# Altium schematic sheet RTL8762CKF_revA.SchDoc(RTL8762CKF_revA)
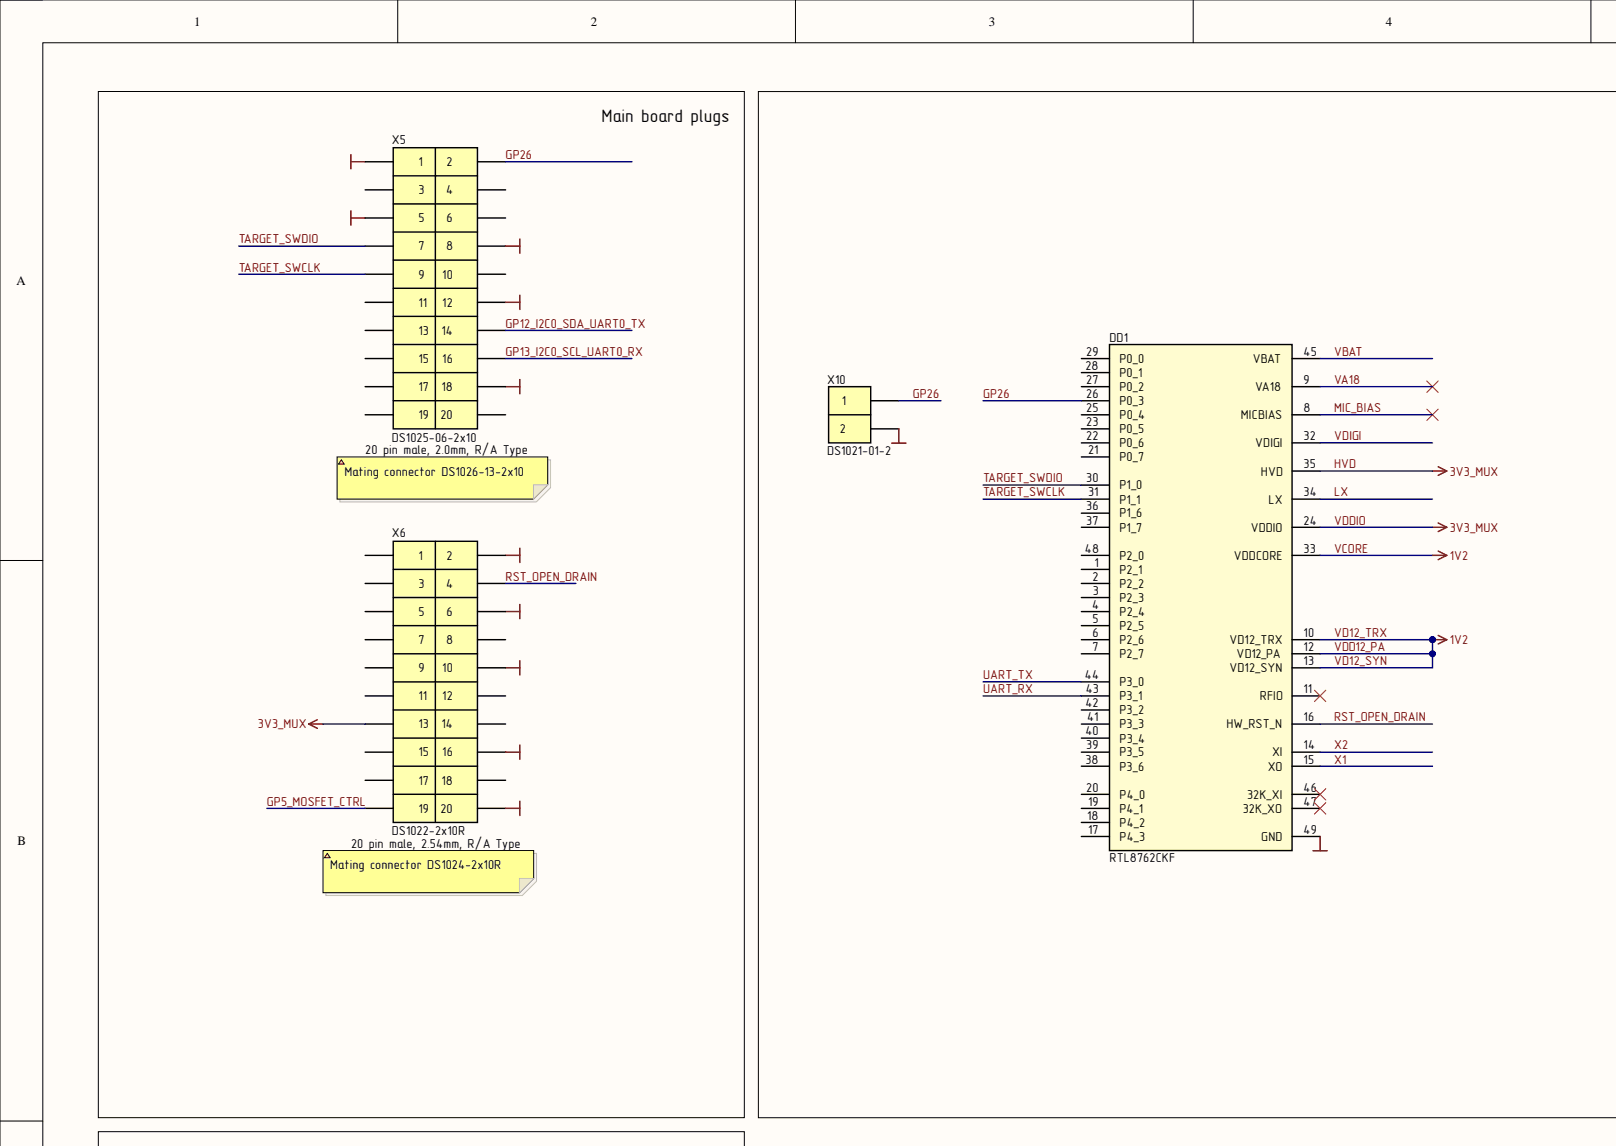
[diagram p0: RTL8762CKF_revA.SchDoc, top left]
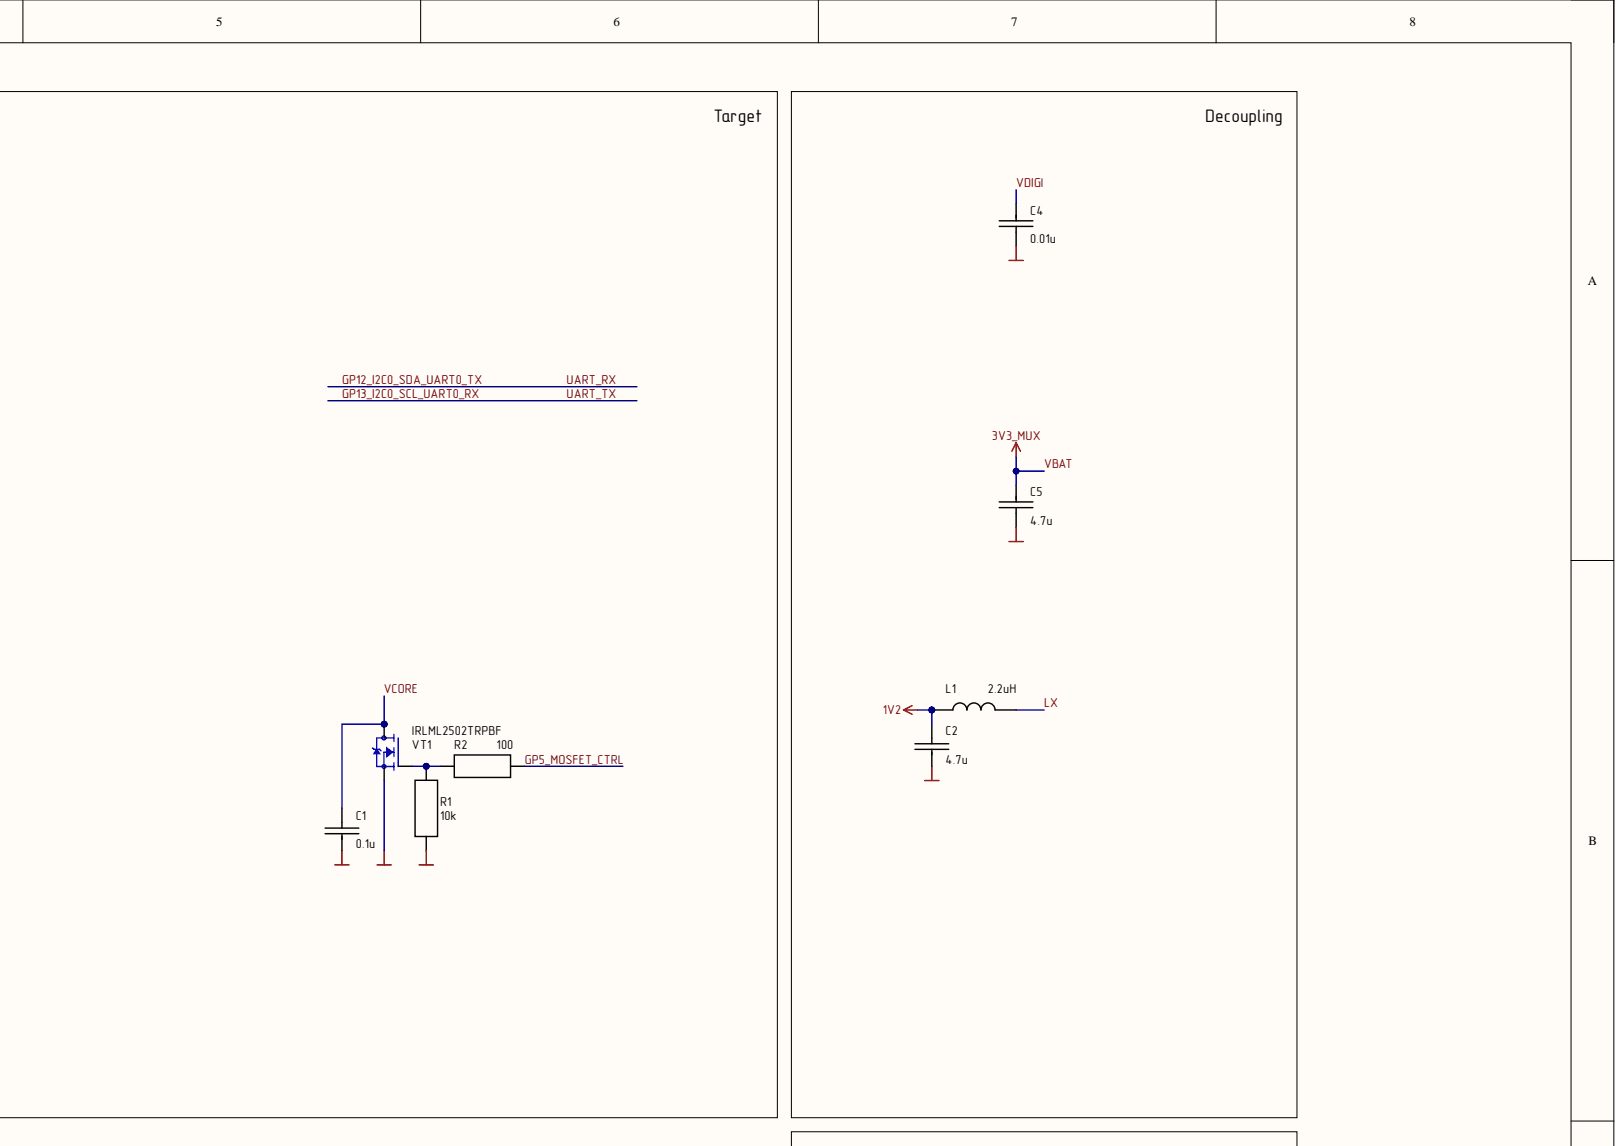
[diagram p1: RTL8762CKF_revA.SchDoc, top right]
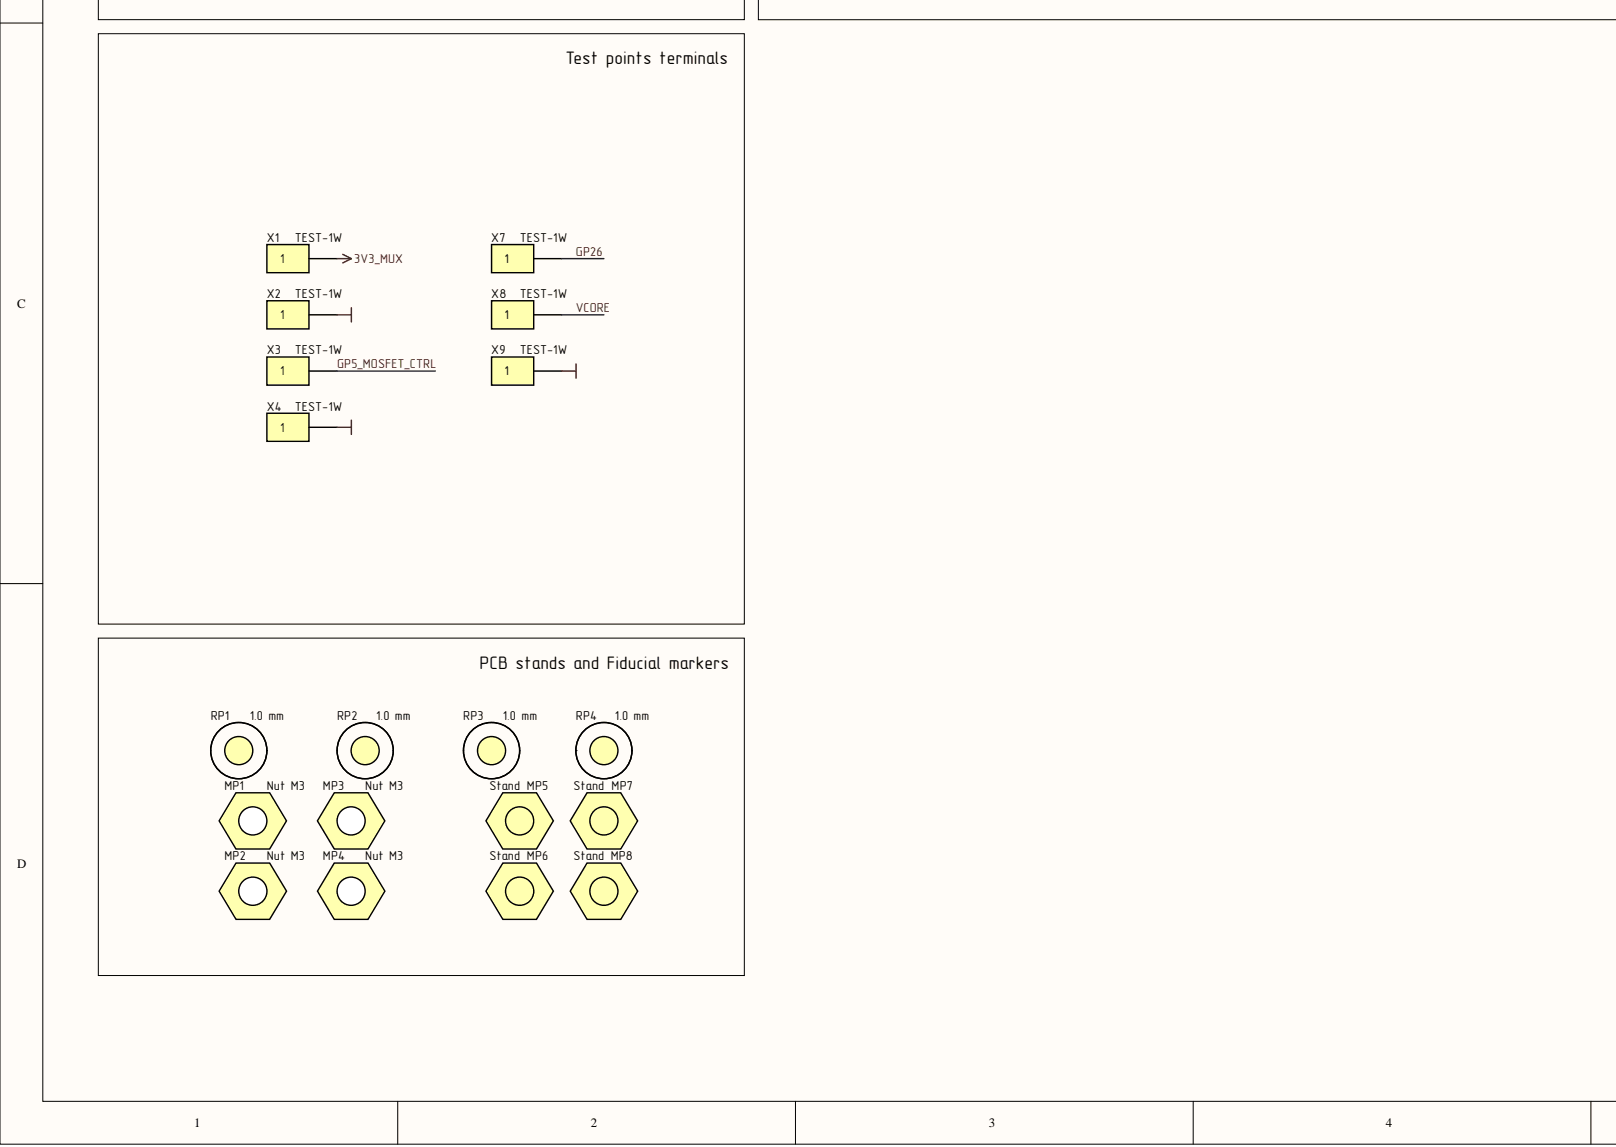
[diagram p2: RTL8762CKF_revA.SchDoc, bottom left]
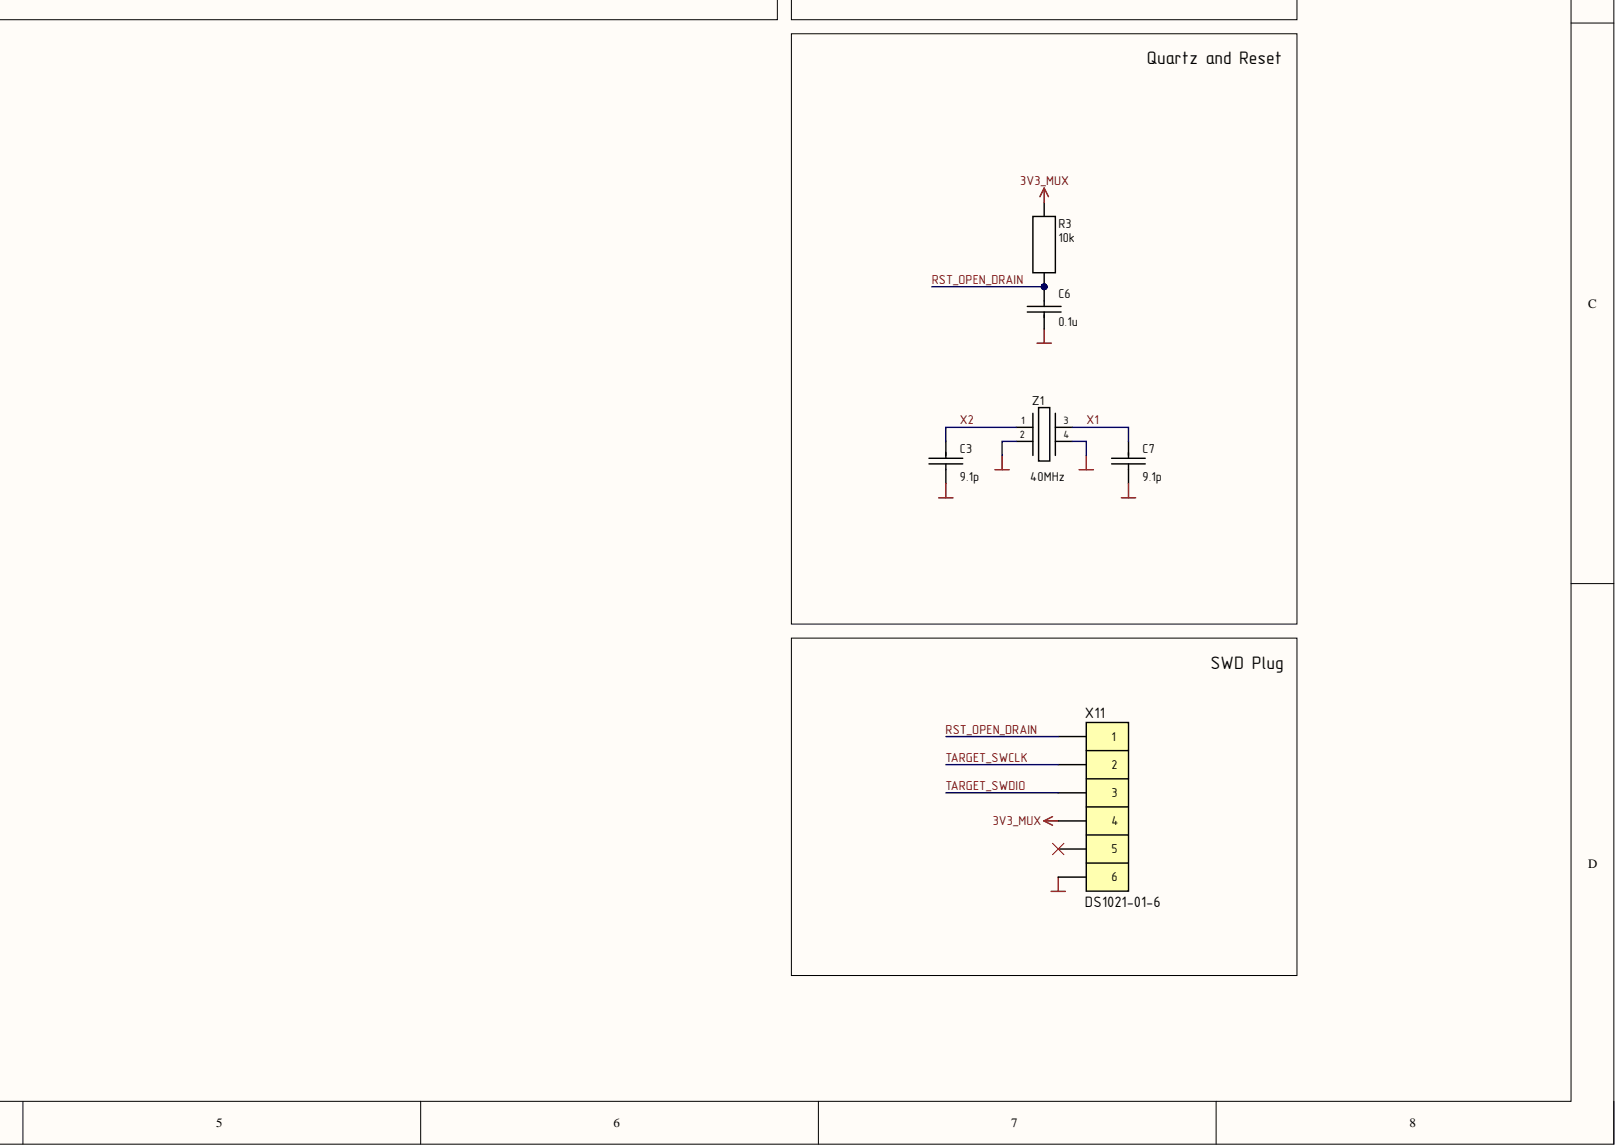
[diagram p3: RTL8762CKF_revA.SchDoc, bottom right]

## Parts
C1  value 0.1u  [CH0603C]  (hidden comment: Ceramic capacitor, 0603, 0.1u, ±10%, 50V, X7R, -55...+125°C)
C2  value 4.7u  [CH0603C]  (hidden comment: Ceramic capacitor, 0603, 4.7u, ±10%, 16V, X7R, -55...+125°C)
C3  value 9.1p  [CH0603C]  (hidden comment: Ceramic capacitor, 0603, 9.1p, ±10%, 100V, C0G, -55...+125°C)
C4  value 0.01u  [CH0603C]  (hidden comment: Ceramic capacitor, 0603, 0.01u, ±10%, 100V, X7R, -55...+125°C)
C5  value 4.7u  [CH0603C]  (hidden comment: Ceramic capacitor, 0603, 4.7u, ±10%, 10V, X7R, -55...+125°C)
C6  value 0.1u  [CH0603C]  (hidden comment: Ceramic capacitor, 0603, 0.1u, ±10%, 50V, X7R, -55...+125°C)
C7  value 9.1p  [CH0603C]  (hidden comment: Ceramic capacitor, 0603, 9.1p, ±10%, 100V, C0G, -55...+125°C)
DD1  RTL8762CKF  [QFN40P600X600X90-49N]
L1  2.2uH  [INDC 0805(2012)X145N]
MP1  Nut M3  [Stand]  (mechanical)
MP2  Nut M3  [Stand]  (mechanical)
MP3  Nut M3  [Stand]  (mechanical)
MP4  Nut M3  [Stand]  (mechanical)
MP5  Stand  [Stand]
MP6  Stand  [Stand]
MP7  Stand  [Stand]
MP8  Stand  [Stand]
R1  value 10k  [CH0603R]  (hidden comment: Resistor thick-film, 0603, 10k, ±5%, 0.1W, -55...+125°C)
R2  value 100  [CH0603R]  (hidden comment: Resistor thick-film, 0603, 100, ±5%, 0.1W, -55...+125°C)
R3  value 10k  [CH0603R]  (hidden comment: Resistor thick-film, 0603, 10k, ±5%, 0.1W, -55...+125°C)
RP1  1.0 mm  [REPER-10]  (no BOM)
RP2  1.0 mm  [REPER-10]  (no BOM)
RP3  1.0 mm  [REPER-10]  (no BOM)
RP4  1.0 mm  [REPER-10]  (no BOM)
VT1  IRLML2502TRPBF  [SOT23]
X1  PartNumber: TEST-1W  [TEST-1W 1pin MULTICOMP]  (hidden comment: VDD)
X2  PartNumber: TEST-1W  [TEST-1W 1pin MULTICOMP]  (hidden comment: GND)
X3  GP5  PartNumber: TEST-1W  [TEST-1W 1pin MULTICOMP]
X4  PartNumber: TEST-1W  [TEST-1W 1pin MULTICOMP]  (hidden comment: GND)
X5  DS1025-06-2x10  [DS1025-06-2x10]
X6  DS1022-2x10R  [DS1022-2x10R]
X7  PartNumber: TEST-1W  [TEST-1W 1pin MULTICOMP]  (hidden comment: P0_3)
X8  VCORE  PartNumber: TEST-1W  [TEST-1W 1pin MULTICOMP]
X9  PartNumber: TEST-1W  [TEST-1W 1pin MULTICOMP]  (hidden comment: GND)
X10  DS1021-01-2  [DS1021-01-2  2.54]
X11  DS1021-01-6  [DS1021-01-6  2.54]
Z1  40MHz  [OSC: 3225]

## Nets
GND: C1.1 C2.1 C3.2 C4.2 C5.2 C6.1 C7.2 DD1.49(GND) R1.1 VT1.2 X2.1 X4.1 X5.1 X5.5 X5.8 X5.12 X5.18 X6.2 X6.6 X6.10 X6.16 X6.20 X9.1 X10.2 X11.6 Z1.2 Z1.4
GP5_MOSFET_CTRL: R2.1 X3.1 X6.19
GP12_I2C0_SDA_UART0_TX: DD1.43(P3_1) X5.14
GP13_I2C0_SCL_UART0_RX: DD1.44(P3_0) X5.16
GP26: DD1.26(P0_3) X5.2 X7.1 X10.1
HVD: C5.1 DD1.24(VDDIO) DD1.35(HVD) DD1.45(VBAT) R3.2 X1.1 X6.13 X11.4
LX: DD1.34(LX) L1.1
MIC_BIAS: DD1.8(MICBIAS)
RST_OPEN_DRAIN: C6.2 DD1.16(HW_RST_N) R3.1 X6.4 X11.1
TARGET_SWCLK: DD1.31(P1_1) X5.9 X11.2
TARGET_SWDIO: DD1.30(P1_0) X5.7 X11.3
VA18: DD1.9(VA18)
VCORE: C1.2 C2.2 DD1.10(VD12_TRX) DD1.12(VD12_PA) DD1.13(VD12_SYN) DD1.33(VDDCORE) L1.2 VT1.3 X8.1
VDIGI: C4.1 DD1.32(VDIGI)
X1: C7.1 DD1.15(XO) Z1.3
X2: C3.1 DD1.14(XI) Z1.1
NetR1_2: R1.2 R2.2 VT1.1

Not connected: DD1 1(P2_1) 2(P2_2) 3(P2_3) 4(P2_4) 5(P2_5) 6(P2_6) 7(P2_7) 11(RFIO) 17(P4_3) 18(P4_2) 19(P4_1) 20(P4_0) 21(P0_7) 22(P0_6) 23(P0_5) 25(P0_4) 27(P0_2) 28(P0_1) 29(P0_0) 36(P1_6) 37(P1_7) 38(P3_6) 39(P3_5) 40(P3_4) 41(P3_3) 42(P3_2) 46(32K_XI) 47(32K_XO) 48(P2_0); MP5 1; MP6 1; MP7 1; MP8 1; RP1 1; RP2 1; RP3 1; RP4 1; X5 3 4 6 10 11 13 15 17 19 20; X6 1 3 5 7 8 9 11 12 14 15 17 18; X11 5

## Library data of the parts
C1 C6 = Capacitor: Manufacturer: Murata; PartNumber: GRM188R71H104K; Type: SMD; Component Kind: Standard; ComponentType: Ceramic capacitor; Description/Value: Ceramic capacitor, 0603, 0.1u, ±10%, 50V, X7R, -55...+125°C; HelpURL: ?; Library Name: Common Lib.SchLib; Package: 0603; Temperature: -55...+125°C; Tolerance: ±10%; TypeDielectric: X7R; Voltage: 50V
C2 = Capacitor: Manufacturer: Murata; PartNumber: GRM188Z71C475KE21D; Type: SMD; Component Kind: Standard; ComponentType: Ceramic capacitor; Description/Value: Ceramic capacitor, 0603, 4.7u, ±10%, 16V, X7R, -55...+125°C; HelpURL: ?; Library Name: Common Lib.SchLib; Package: 0603; Temperature: -55...+125°C; Tolerance: ±10%; TypeDielectric: X7R; Voltage: 16V
C3 C7 = Capacitor: Manufacturer: Murata; PartNumber: CC0603CRNPO9BN9R1; Type: SMD; Component Kind: Standard; ComponentType: Ceramic capacitor; Description/Value: Ceramic capacitor, 0603, 9.1p, ±10%, 100V, C0G, -55...+125°C; HelpURL: ?; Library Name: Common Lib.SchLib; Package: 0603; Temperature: -55...+125°C; Tolerance: ±10%; TypeDielectric: C0G; Voltage: 100V
C4 = Capacitor: Manufacturer: Murata; PartNumber: GCM188R72A103KA37D; Type: SMD; Component Kind: Standard; ComponentType: Ceramic capacitor; Description/Value: Ceramic capacitor, 0603, 0.01u, ±10%, 100V, X7R, -55...+125°C; HelpURL: ?; Library Name: Common Lib.SchLib; Package: 0603; Temperature: -55...+125°C; Tolerance: ±10%; TypeDielectric: X7R; Voltage: 100V
C5 = Capacitor: Manufacturer: Murata; PartNumber: GRM188Z71A475KE15D; Type: SMD; Component Kind: Standard; ComponentType: Ceramic capacitor; Description/Value: Ceramic capacitor, 0603, 4.7u, ±10%, 10V, X7R, -55...+125°C; HelpURL: ?; Library Name: Common Lib.SchLib; Package: 0603; Temperature: -55...+125°C; Tolerance: ±10%; TypeDielectric: X7R; Voltage: 10V
DD1 = RTL8762CKF: RTL8762CKF, QFN-48 6x6mm; Component Kind: Standard; Description/Value: RTL8762CKF, QFN-48 6x6mm; Library Name: Common Lib.SchLib; PartNumber: RTL8762CKF; Supplier Footprint: QFN-48 (6x6)
L1 = Inductor: PartNumber: LQM21PN2R2NGRD; Current: 0.8 A; Description/Value: Inductor, 0805, 2.2uH, 0.8 A; Max freq: 30 MHz; Resistance: 0.23 Ohm; Component Kind: Standard; ComponentType: Inductor, 0805; Library Name: Common Lib.SchLib; Value: 2.2uH
MP1-MP4 = nut: PartNumber: Nut M3 DIN 934; Description/Value: Hexagon Nut M3 DIN 934; Library Name: Common Lib.SchLib
MP5-MP8 = stand: PartNumber: PCHSN-10; Description/Value: PCB brass stand, M3, 10mm; Component Kind: Standard; Library Name: Common Lib.SchLib
R1 R3 = Resistor: Manufacturer: Yageo; PartNumber: RC0603JR-0710KL; Component Kind: Standard; ComponentType: Resistor thick-film; Description/Value: Resistor thick-film, 0603, 10k, ±5%, 0.1W, -55...+125°C; HelpURL: https://datasheet.lcsc.com/lcsc/2009261810_YAGEO-RL0805FR-070R33L_C724024.pdf; Library Name: Common Lib.SchLib; Package: 0603; Power: 0.1W; Temperature: -55...+125°C; Tolerance: ±5%; Type: SMD
R2 = Resistor: Manufacturer: Yageo; PartNumber: RC0603JR-07100RL; Component Kind: Standard; ComponentType: Resistor thick-film; Description/Value: Resistor thick-film, 0603, 100, ±5%, 0.1W, -55...+125°C; HelpURL: https://datasheet.lcsc.com/lcsc/2009261810_YAGEO-RL0805FR-070R33L_C724024.pdf; Library Name: Common Lib.SchLib; Package: 0603; Power: 0.1W; Temperature: -55...+125°C; Tolerance: ±5%; Type: SMD
RP1-RP4 = CMP-00005-2: Design Item ID: REPER; Source: Common Lib.SchLib; Library Name: Positive Labs Server
VT1 = MOSFET N: RoHS Compliant | Industry-leading quality | Fast Switching | Low Profile _less than 1.1mm_ | SOT-23 Footprint; Description/Value: N-MOSFET Transistor, 20V, 4.2A, SOT-23-23; Component Kind: Standard; Library Name: Common Lib.SchLib; Package: SOT-23; PartNumber: IRLML2502TRPBF
X1-X4 X7-X9 = 1-Connector: Description/Value: PCB Test Point Through Hole Mount; Component Kind: Standard; Library Name: Common Lib.SchLib
X5 = 20-Connector: Description/Value: PLD Connector 20 pin, 2.0mm, Male, DS1025-06-2x10; Component Kind: Standard; ComponentKind: PLD Connector 20 pin, 2.0mm, Male; Library Name: Common Lib.SchLib; PartNumber: DS1025-06-2x10
X6 = 20-Connector: Description/Value: PLD Connector 20 pin, 2.54mm, Male, DS1022-2x10R; Component Kind: Standard; ComponentKind: PLD Connector 20 pin, 2.54mm, Male; Library Name: Common Lib.SchLib; PartNumber: DS1022-2x10R
X10 = 2-Connector: Description/Value: Connector 2 pin; Component Kind: Standard; ComponentKind: Connector 2 pin; PartNumber: DS1021-01-2; Library Name: N_Shadrin.SchLib
X11 = 6-Connector: Description/Value: PLS Connector 6 pins, 2.54mm, Male; Component Kind: Standard; Library Name: Common Lib.SchLib; PartNumber: DS1021-01-6
Z1 = Crystal_3225_25MHz: PartNumber: 7M-40.000MAAJ-T; Description/Value: Crystal, SMD3225C4, 40MHz; Component Kind: Standard; Library Name: Common Lib.SchLib
